# Altium schematic sheet PORT.SchDoc
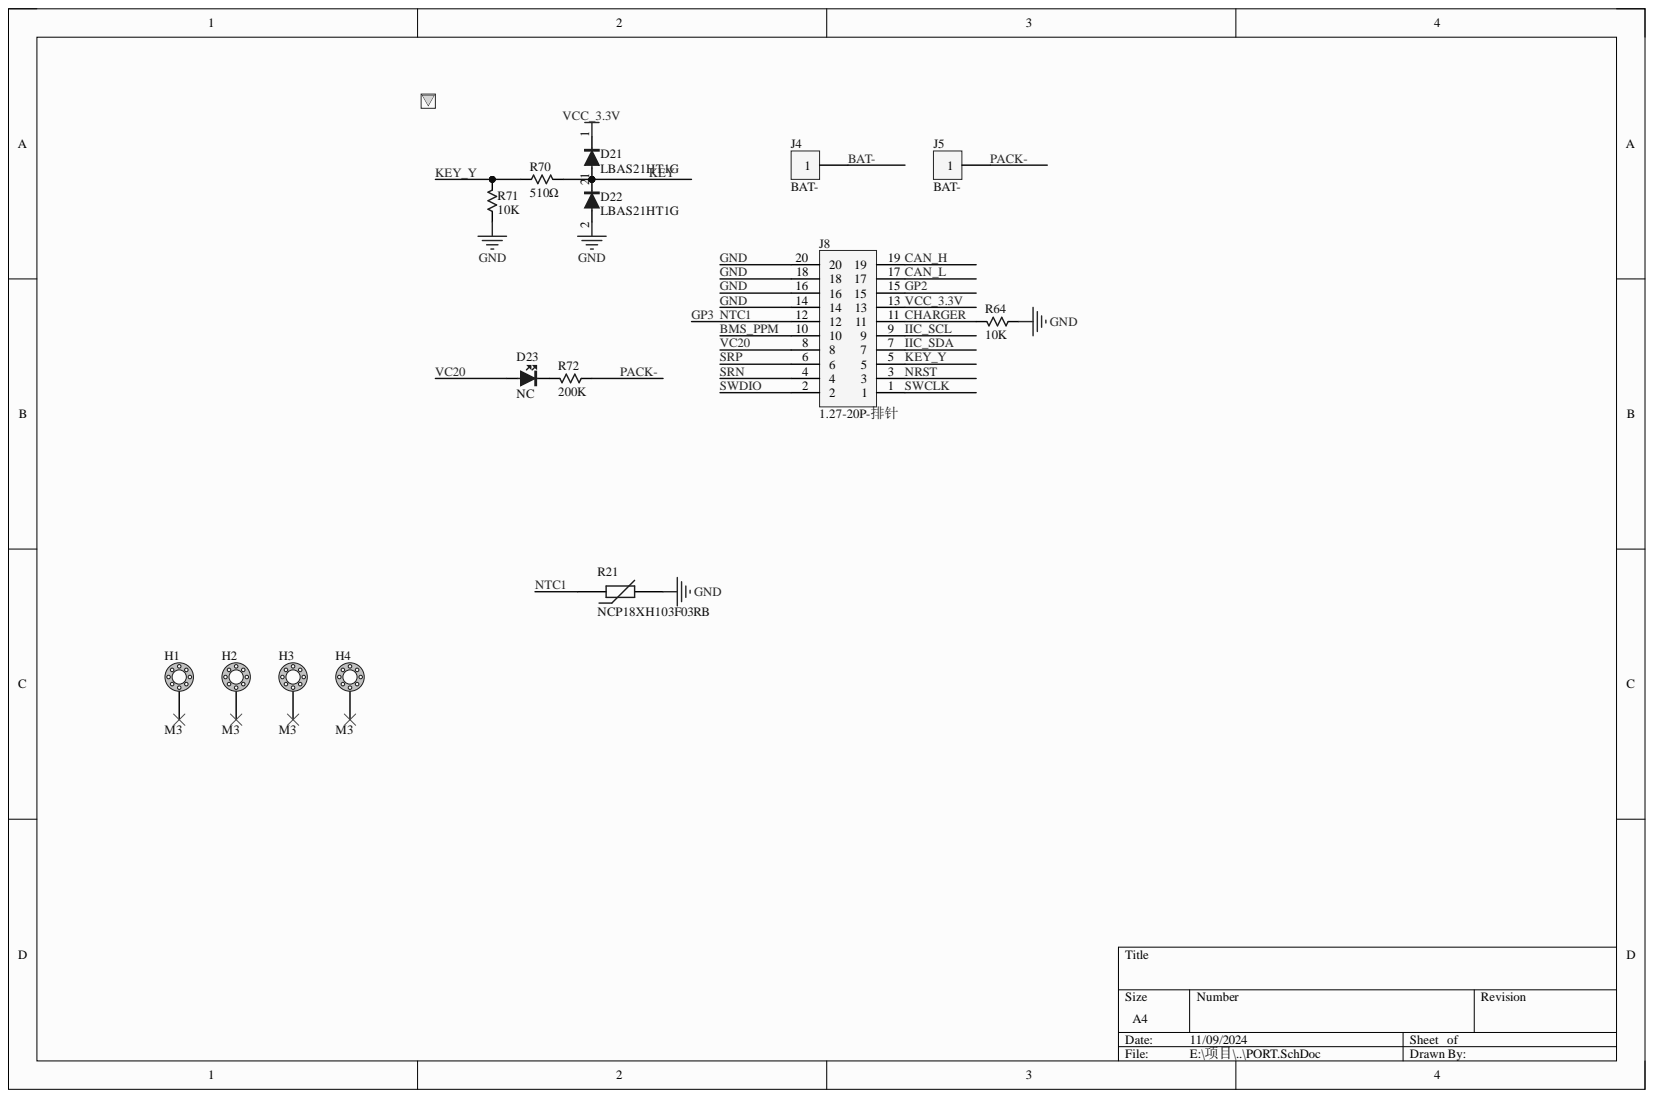
[diagram p0: PORT.SchDoc]

## Parts
D21  LBAS21HT1G  [SOD-323]
D22  LBAS21HT1G  [SOD-323]
D23  NC  [LED-0603G]
H1  M3  [M3]
H2  M3  [M3]
H3  M3  [M3]
H4  M3  [M3]
J4  BAT-  [PAD 7*7]
J5  BAT-  [PAD 7*7]
J8  1.27-20P-排针  [CONN-TH_20P-P1.27_KINGHELM_KH-1.27FH-2X10P-H4.3]
R21  NCP18XH103F03RB  [0603_R]
R64  10K  [0603_R]
R70  510Ω  [0603_R]
R71  10K  [0603_R]
R72  200K  [0603_R]

## Nets
BAT-: J4.1
BMS_PPM: J8.10
CAN_H: J8.19
CAN_L: J8.17
CHARGER: J8.11 R64.1
GND: D22.2 J8.14 J8.16 J8.18 J8.20 R21.2 R64.2 R71.1
GP2: J8.15
GP3: J8.12 R21.1
IIC_SCL: J8.9
IIC_SDA: J8.7
KEY: D21.2 D22.1 R70.1
KEY_Y: J8.5 R70.2 R71.2
NRST: J8.3
PACK-: J5.1 R72.1
SRN: J8.4
SRP: J8.6
SWCLK: J8.1
SWDIO: J8.2
VC20: D23.A J8.8
VCC_3.3V: D21.1 J8.13
NetD23_K: D23.K R72.2

Not connected: H1 1; H2 1; H3 1; H4 1

## Library data of the parts
D21 D22 = 1N4148W: Component Kind: Standard; Library Name: DVC1124_20S.SchLib
D23 = LED: Component Kind: Standard; Library Name: UP-ROBOT Devices.SchLib
H1-H4 = HOLD: Component Kind: Standard; Library Name: UP-ROBOT Devices.SchLib
J4 J5 = 1P: Component Kind: Standard; Library Name: UP-ROBOT Devices.SchLib
J8 = Pin HDR2X10: Component Kind: Standard; Library Name: 排针.SchLib
R21 = Varistor: NTC电阻; Component Kind: Standard; Library Name: 电阻.SchLib
R64 R70-R72 = Res: Resistor; Component Kind: Standard; LatestRevisionDate: 17-Jul-2002; LatestRevisionNote: Re-released for DXP Platform.; PackageReference: AXIAL-0.3; Published: 8-Jun-2000; Signal Integrity: Res; Simulation: RESISTOR; Library Name: UP-ROBOT Devices.SchLib; Publisher: Altium Limited
  R64 R71: Value: 10K
  R70: Value: 510Ω
  R72: Value: 200K
